# Altium schematic sheet 100_USB_HUB.SchDoc
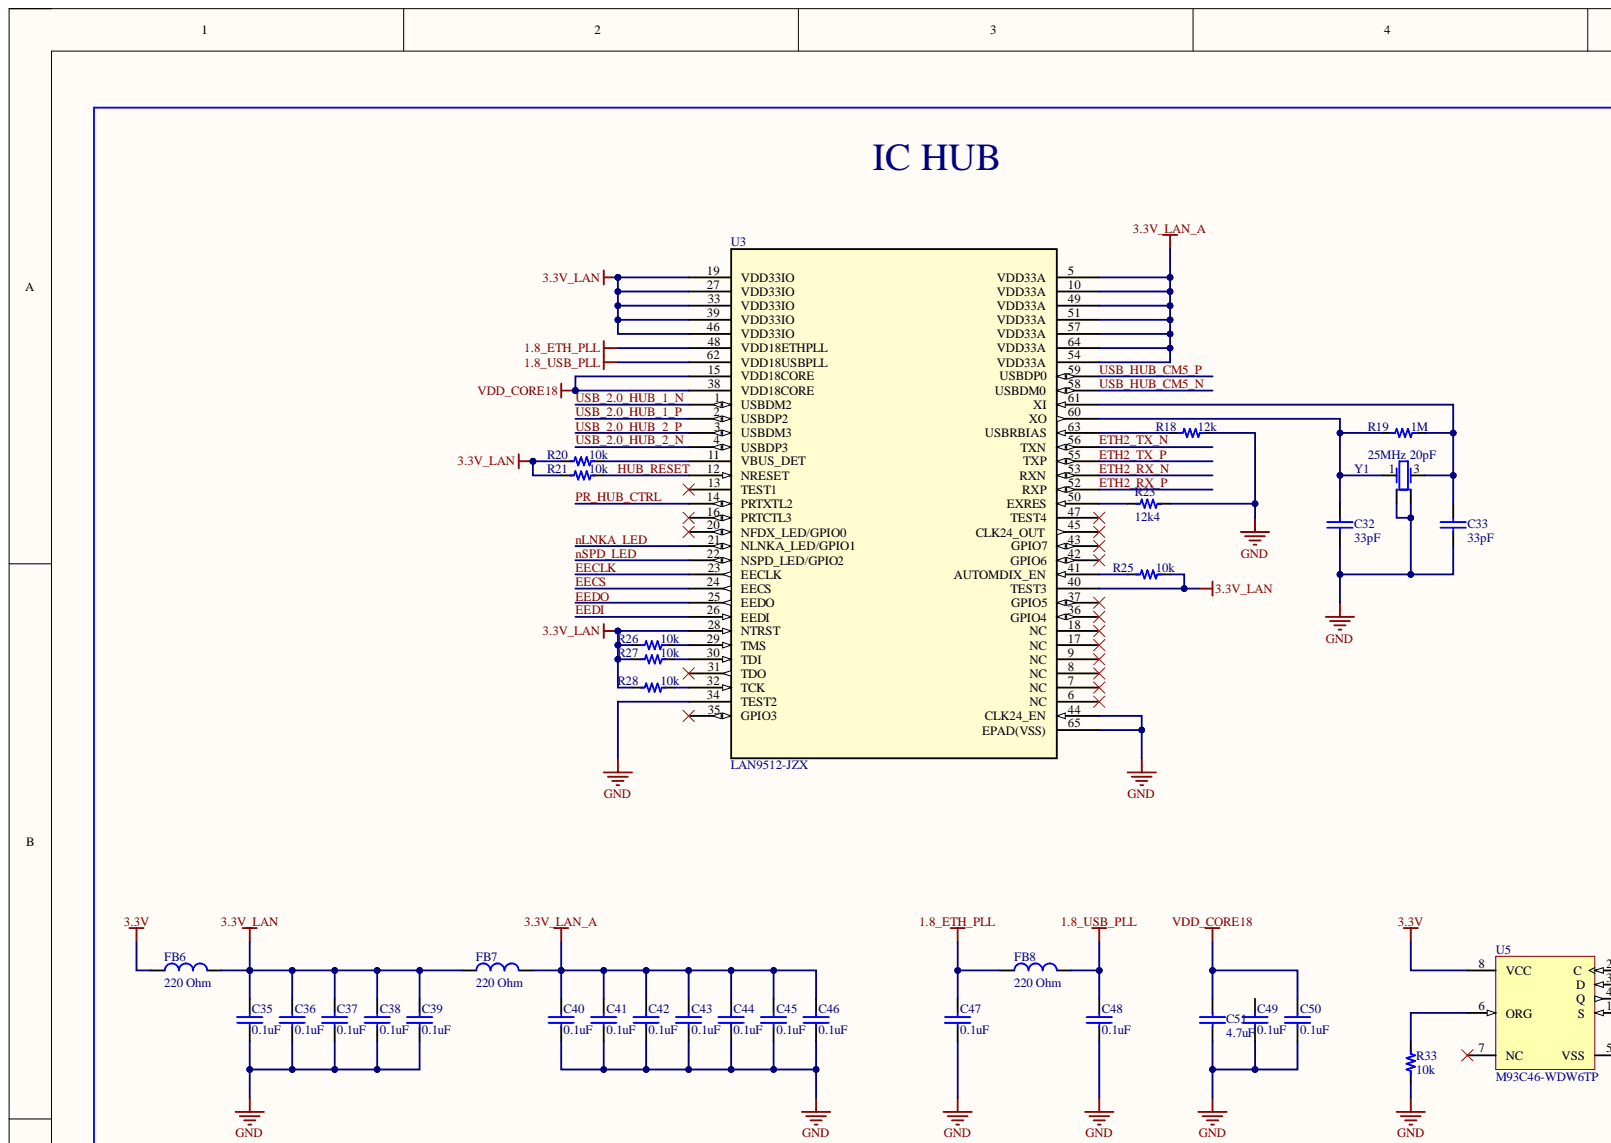
[diagram p0: 100_USB_HUB.SchDoc, top left]
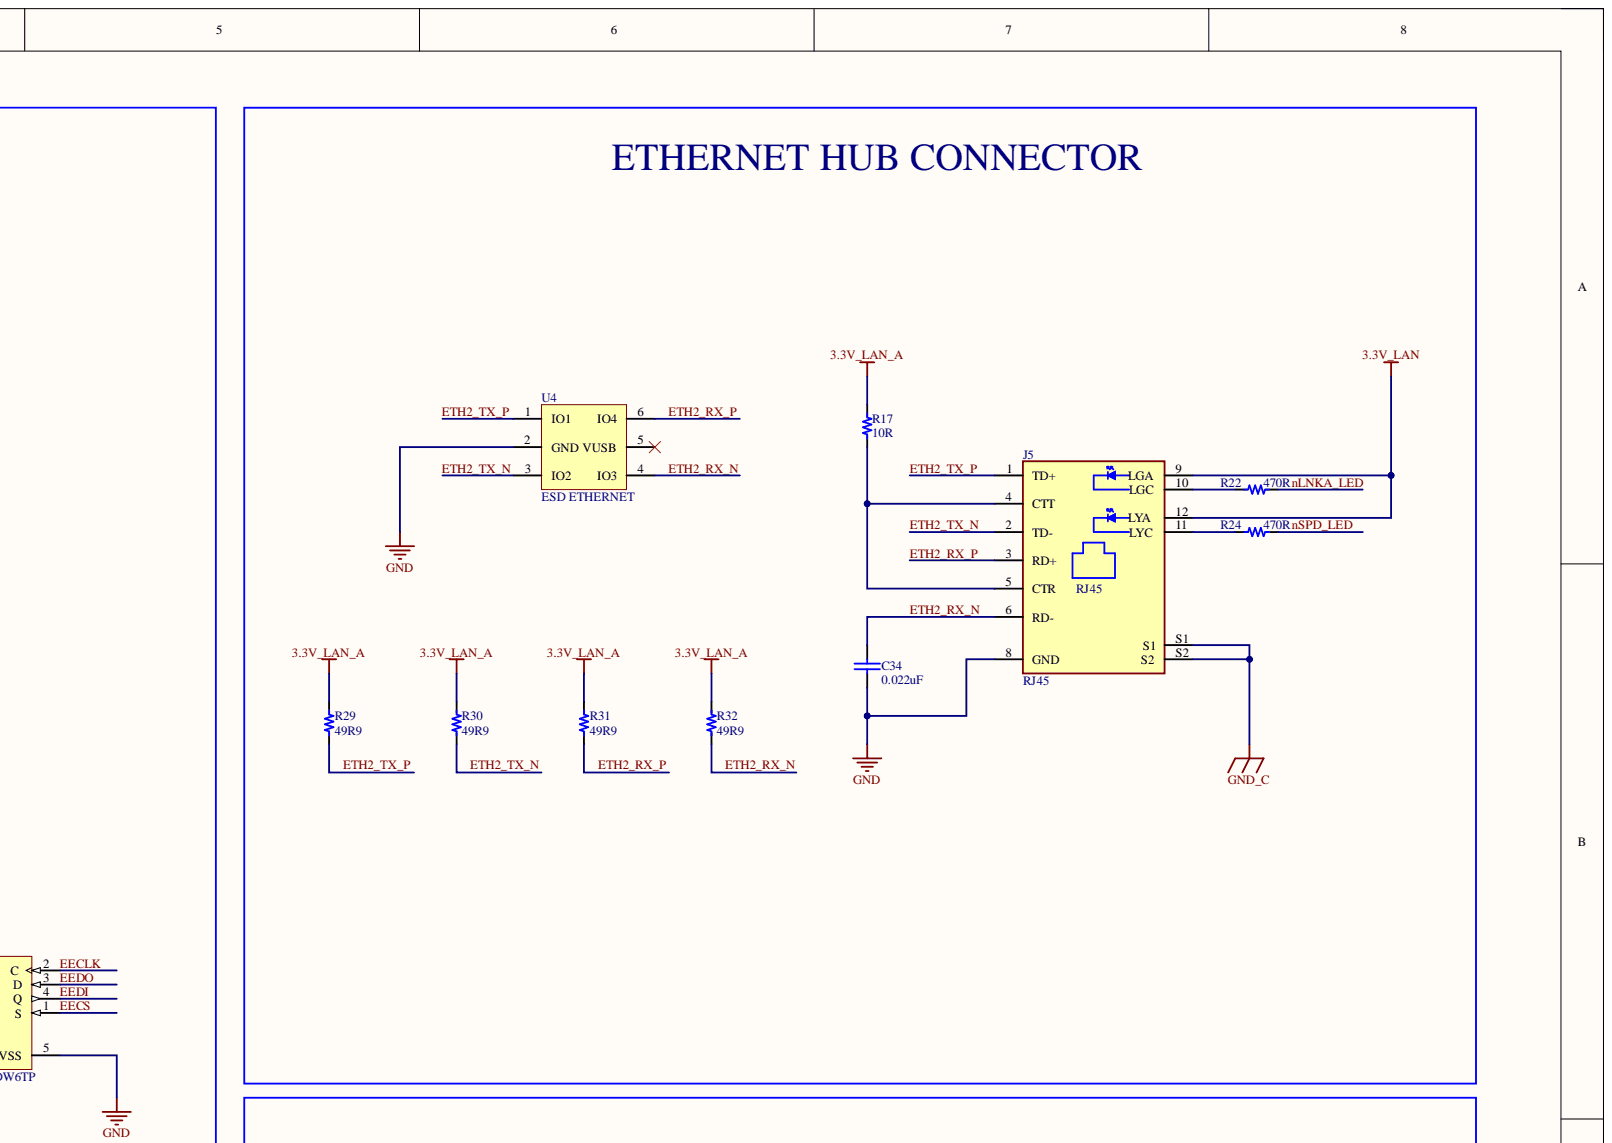
[diagram p1: 100_USB_HUB.SchDoc, top right]
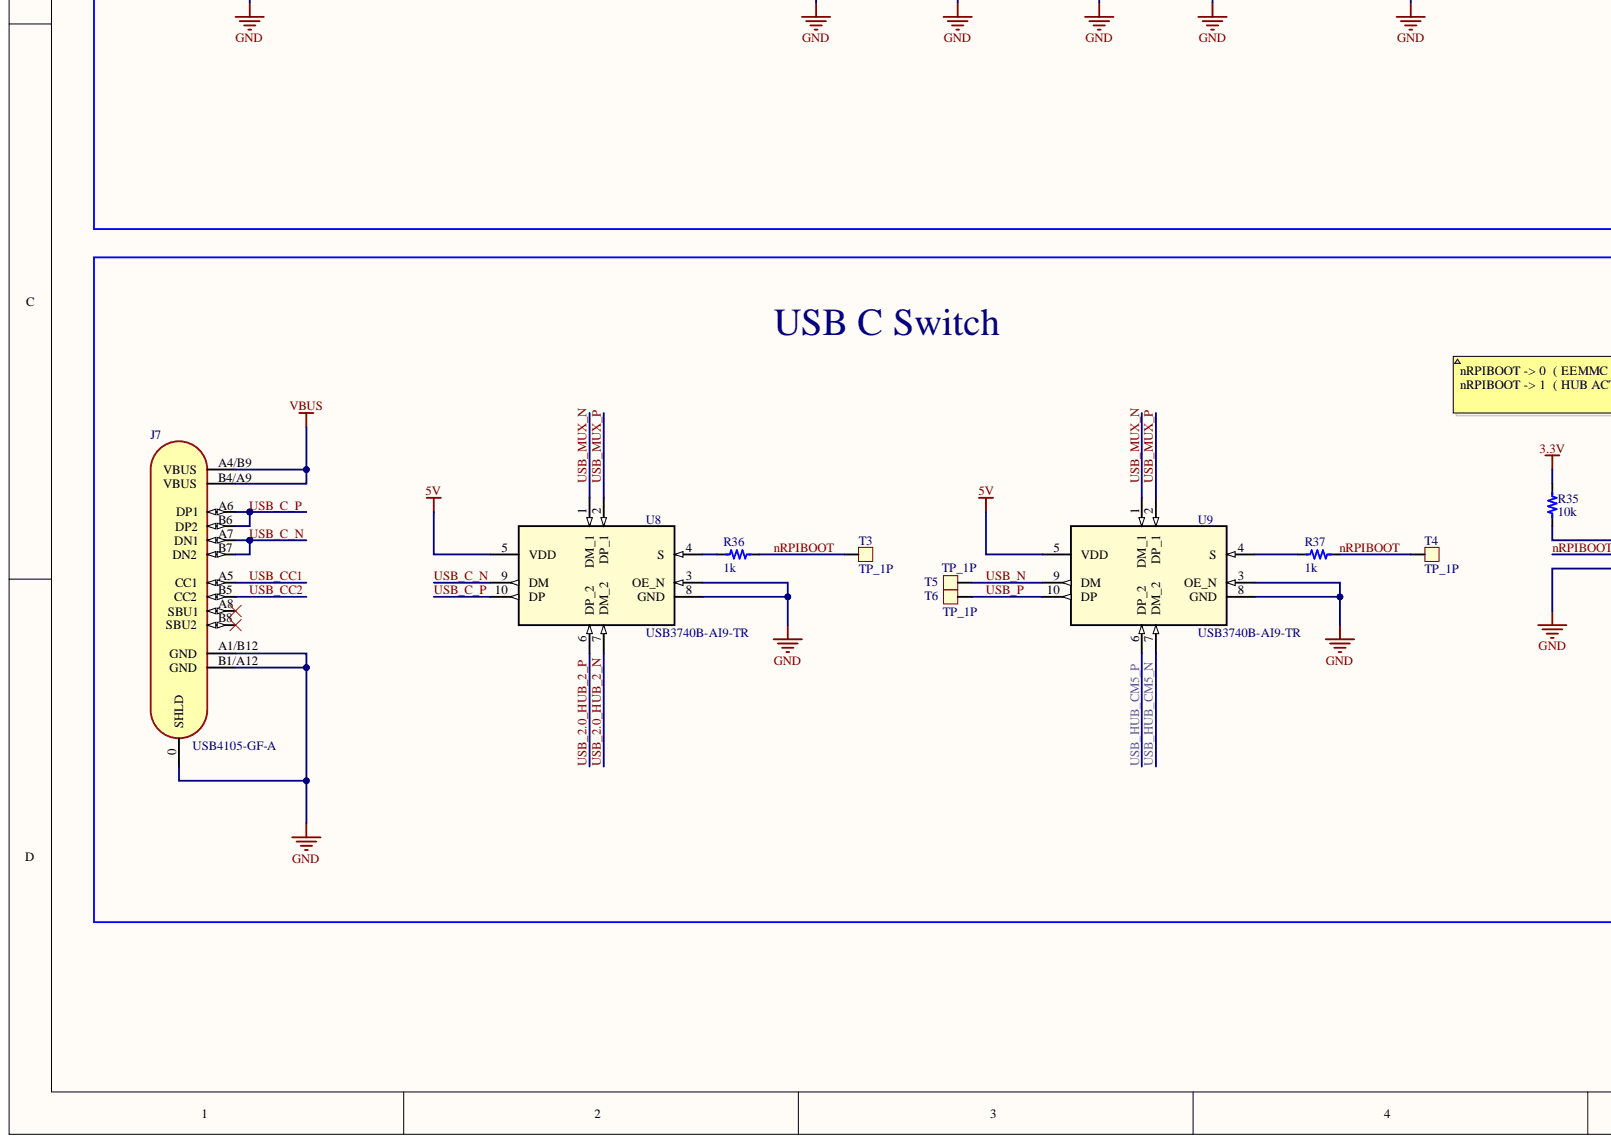
[diagram p2: 100_USB_HUB.SchDoc, bottom left]
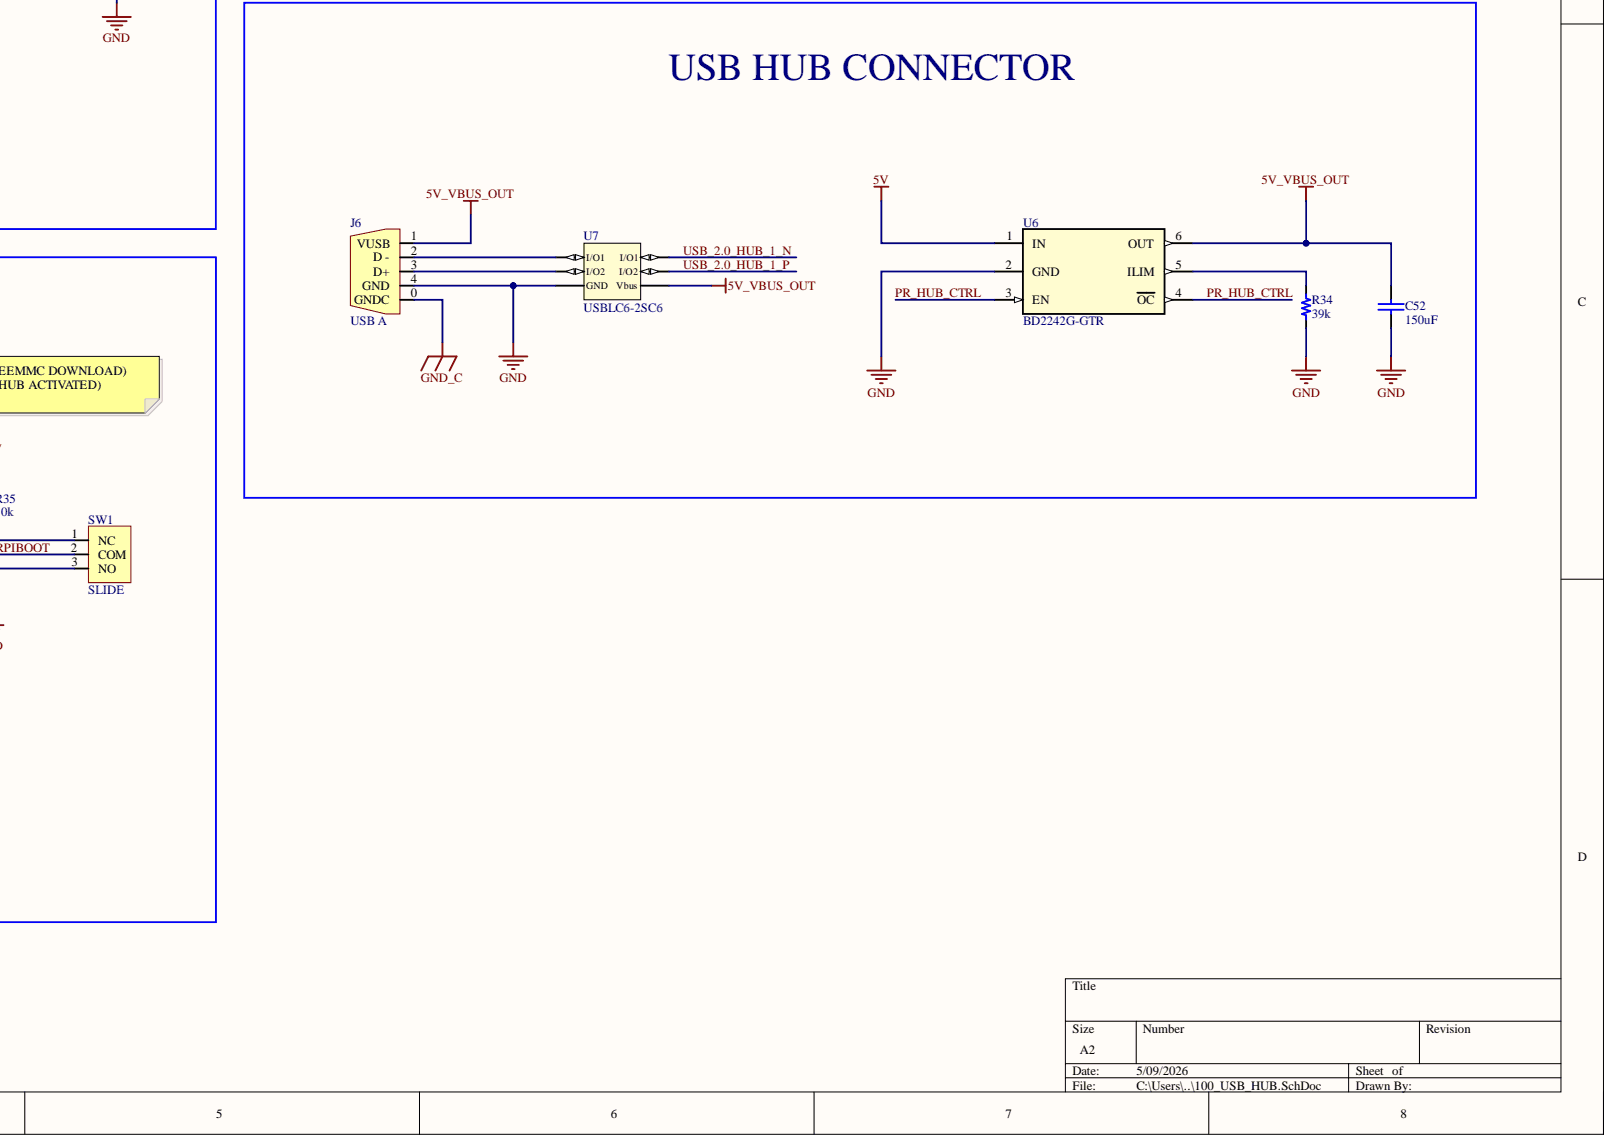
[diagram p3: 100_USB_HUB.SchDoc, bottom right]

## Parts
C32  value 33pF  [0603_1.4mm]  (hidden comment: 33pF 50V)
C33  value 33pF  [0603_1.4mm]  (hidden comment: 33pF 50V)
C34  value 0.022uF  [0402_1.0mm]  (hidden comment: 0.022uF 50V)
C35  value 0.1uF  [0603_1.4mm]  (hidden comment: 0.1uF 100V)
C36  value 0.1uF  [0603_1.4mm]  (hidden comment: 0.1uF 100V)
C37  value 0.1uF  [0603_1.4mm]  (hidden comment: 0.1uF 100V)
C38  value 0.1uF  [0603_1.4mm]  (hidden comment: 0.1uF 100V)
C39  value 0.1uF  [0603_1.4mm]  (hidden comment: 0.1uF 100V)
C40  value 0.1uF  [0603_1.4mm]  (hidden comment: 0.1uF 100V)
C41  value 0.1uF  [0603_1.4mm]  (hidden comment: 0.1uF 100V)
C42  value 0.1uF  [0603_1.4mm]  (hidden comment: 0.1uF 100V)
C43  value 0.1uF  [0603_1.4mm]  (hidden comment: 0.1uF 100V)
C44  value 0.1uF  [0603_1.4mm]  (hidden comment: 0.1uF 100V)
C45  value 0.1uF  [0603_1.4mm]  (hidden comment: 0.1uF 100V)
C46  value 0.1uF  [0603_1.4mm]  (hidden comment: 0.1uF 100V)
C47  value 0.1uF  [0603_1.4mm]  (hidden comment: 0.1uF 100V)
C48  value 0.1uF  [0603_1.4mm]  (hidden comment: 0.1uF 100V)
C49  value 0.1uF  [0603_1.4mm]  (hidden comment: 0.1uF 100V)
C50  value 0.1uF  [0603_1.4mm]  (hidden comment: 0.1uF 100V)
C51  value 4.7uF  [0603_1.4mm]  (hidden comment: 4.7uF 6.3V)
C52  value 150uF  [1210_3.2mm]  (hidden comment: 150uF 6.3V)
FB6  value 220 Ohm  [0603]  (hidden comment: 220OHM 2.2A)
FB7  value 220 Ohm  [0603]  (hidden comment: 220OHM 2.2A)
FB8  value 220 Ohm  [0603]  (hidden comment: 220OHM 2.2A)
J5  RJ45  [Con_RJ45_1Port_10/100BASE]
J6  USB A  [CON_USB_TYPE_A_FEMALE]
J7  USB4105-GF-A  [CON_USB_C_16P_RA]
R17  value 10R  [0402_1.0mm]  (hidden comment: 10R 1/16W)
R18  value 12k  [0603_1.4mm]  (hidden comment: 12k 1/10W)
R19  value 1M  [0603_1.4mm]  (hidden comment: 1M 1/10W)
R20  value 10k  [0603_1.4mm]  (hidden comment: 10k 1/10W)
R21  value 10k  [0603_1.4mm]  (hidden comment: 10k 1/10W)
R22  value 470R  [0603_1.4mm]  (hidden comment: 470R 1/10W)
R23  value 12k4  [0603_1.4mm]  (hidden comment: 12k4 1/10W)
R24  value 470R  [0603_1.4mm]  (hidden comment: 470R 1/10W)
R25  value 10k  [0603_1.4mm]  (hidden comment: 10k 1/10W)
R26  value 10k  [0603_1.4mm]  (hidden comment: 10k 1/10W)
R27  value 10k  [0603_1.4mm]  (hidden comment: 10k 1/10W)
R28  value 10k  [0603_1.4mm]  (hidden comment: 10k 1/10W)
R29  value 49R9  [0402_1.0mm]  (hidden comment: 49R9 1/16W)
R30  value 49R9  [0402_1.0mm]  (hidden comment: 49R9 1/16W)
R31  value 49R9  [0402_1.0mm]  (hidden comment: 49R9 1/16W)
R32  value 49R9  [0402_1.0mm]  (hidden comment: 49R9 1/16W)
R33  value 10k  [0603_1.4mm]  (hidden comment: 10k 1/10W)
R34  39K  [0603_1.4mm]
R35  value 10k  [0603_1.4mm]  (hidden comment: 10k 1/10W)
R36  value 1k  [0603_1.4mm]  (hidden comment: 1k 1/10W)
R37  value 1k  [0603_1.4mm]  (hidden comment: 1k 1/10W)
SW1  SLIDE  [Sw_SLIDE_SPDT_3.5x3.6x9mm]
T3  TP_1P  [TP_TH_30mil]  (no BOM)
T4  TP_1P  [TP_TH_30mil]  (no BOM)
T5  TP_1P  [TP_SMD_25mil]  (no BOM)
T6  TP_1P  [TP_SMD_25mil]  (no BOM)
U3  LAN9512-JZX  [64-VFQFN_EP]
U4  ESD ETHERNET  [SOT_23_6]
U5  M93C46-WDW6TP  [TSSOP_8_4.40MM]
U6  BD2242G-GTR  [SSOP_6_2.8X2.9MM]
U7  USBLC6-2SC6  [SOT_23_6]
U8  USB3740B-AI9-TR  [10-QFN]
U9  USB3740B-AI9-TR  [10-QFN]
Y1  25MHz 20pF  [SMD_4_3.2X2.5mm]

## Nets
1.8_ETH_PLL: C47.1 FB8.1 U3.48(VDD18ETHPLL)
1.8_USB_PLL: C48.1 FB8.2 U3.62(VDD18USBPLL)
3.3V: FB6.1 R35.1 U5.8(VCC)
3.3V_LAN: C35.1 C36.1 C37.1 C38.1 C39.1 FB6.2 FB7.1 J5.9(LGA) J5.12(LYA) R20.1 R21.1 R25.2 R26.1 R27.1 R28.1 U3.19(VDD33IO) U3.27(VDD33IO) U3.28(NTRST) U3.33(VDD33IO) U3.39(VDD33IO) U3.40(TEST3) U3.46(VDD33IO)
3.3V_LAN_A: C40.1 C41.1 C42.1 C43.1 C44.1 C45.1 C46.1 FB7.2 R17.2 R29.2 R30.2 R31.2 R32.2 U3.5(VDD33A) U3.10(VDD33A) U3.49(VDD33A) U3.51(VDD33A) U3.54(VDD33A) U3.57(VDD33A) U3.64(VDD33A)
5V: U6.1(IN) U8.5(VDD) U9.5(VDD)
5V_VBUS_OUT: C52.2 J6.1(VUSB) U6.6(OUT) U7.5(Vbus)
GND: C32.2 C33.2 C34.2 C35.2 C36.2 C37.2 C38.2 C39.2 C40.2 C41.2 C42.2 C43.2 C44.2 C45.2 C46.2 C47.2 C48.2 C49.2 C50.2 C51.2 C52.1 J5.8(GND) J6.4(GND) J7.0(SHLD) J7.A1/B12(GND) J7.B1/A12(GND) R18.2 R23.2 R33.1 R34.1 SW1.3 U3.34(TEST2) U3.44(CLK24_EN) U3.65(EPAD(VSS)) U4.2(GND) U5.5(VSS) U6.2(GND) U7.2(GND) U8.3(OE_N) U8.8(GND) U9.3(OE_N) U9.8(GND) Y1.2 Y1.4
GND_C: J5.S1 J5.S2 J6.0(GNDC)
VBUS: J7.A4/B9(VBUS) J7.B4/A9(VBUS)
VDD_CORE18: C50.1 C51.1 U3.15(VDD18CORE) U3.38(VDD18CORE)
EECLK: U3.23(EECLK) U5.2(C)
EECS: U3.24(EECS) U5.1(S)
EEDI: U3.26(EEDI) U5.4(Q)
EEDO: U3.25(EEDO) U5.3(D)
ETH2_RX_N: C34.1 J5.6(RD-) R32.1 U3.53(RXN) U4.4(IO3)
ETH2_RX_P: J5.3(RD+) R31.1 U3.52(RXP) U4.6(IO4)
ETH2_TX_N: J5.2(TD-) R30.1 U3.56(TXN) U4.3(IO2)
ETH2_TX_P: J5.1(TD+) R29.1 U3.55(TXP) U4.1(IO1)
HUB_RESET: R21.2 U3.12(NRESET)
nLNKA_LED: R22.2 U3.21(NLNKA_LED/GPIO1)
nRPIBOOT: R36.2 R37.2 SW1.2 T3.1 T4.1
nSPD_LED: R24.2 U3.22(NSPD_LED/GPIO2)
PR_HUB_CTRL: U3.14(PRTXTL2) U6.3(EN) U6.4(OC)
USB_2.0_HUB_1_N: U3.1(USBDM2) U7.6(I/O1)
USB_2.0_HUB_1_P: U3.2(USBDP2) U7.4(I/O2)
USB_2.0_HUB_2_N: U3.4(USBDP3) U8.7(DM_2)
USB_2.0_HUB_2_P: U3.3(USBDM3) U8.6(DP_2)
USB_C_N: J7.A7(DN1) J7.B7(DN2) U8.9(DM)
USB_C_P: J7.A6(DP1) J7.B6(DP2) U8.10(DP)
USB_CC1: J7.A5(CC1)
USB_CC2: J7.B5(CC2)
USB_HUB_CM5_N: U3.58(USBDM0) U9.7(DM_2)
USB_HUB_CM5_P: U3.59(USBDP0) U9.6(DP_2)
USB_MUX_N: U8.1(DM_1) U9.1(DM_1)
USB_MUX_P: U8.2(DP_1) U9.2(DP_1)
USB_N: T5.1 U9.9(DM)
USB_P: T6.1 U9.10(DP)
NetC32_1: C32.1 R19.1 U3.60(XO) Y1.1
NetC33_1: C33.1 R19.2 U3.61(XI) Y1.3
NetJ5_4: J5.4(CTT) J5.5(CTR) R17.1
NetJ5_10: J5.10(LGC) R22.1
NetJ5_11: J5.11(LYC) R24.1
NetJ6_2: J6.2(D_-) U7.1(I/O1)
NetJ6_3: J6.3(D+) U7.3(I/O2)
NetR18_1: R18.1 U3.63(USBRBIAS)
NetR20_2: R20.2 U3.11(VBUS_DET)
NetR23_1: R23.1 U3.50(EXRES)
NetR25_1: R25.1 U3.41(AUTOMDIX_EN)
NetR26_2: R26.2 U3.29(TMS)
NetR27_2: R27.2 U3.30(TDI)
NetR28_2: R28.2 U3.32(TCK)
NetR33_2: R33.2 U5.6(ORG)
NetR34_2: R34.2 U6.5(ILIM)
NetR35_2: R35.2 SW1.1
NetR36_1: R36.1 U8.4(S)
NetR37_1: R37.1 U9.4(S)

Not connected: C49 1; J7 A8(SBU1) B8(SBU2); U3 6(NC) 7(NC) 8(NC) 9(NC) 13(TEST1) 16(PRTCTL3) 17(NC) 18(NC) 20(NFDX_LED/GPIO0) 31(TDO) 35(GPIO3) 36(GPIO4) 37(GPIO5) 42(GPIO6) 43(GPIO7) 45(CLK24_OUT) 47(TEST4); U4 5(VUSB); U5 7(NC)

## Library data of the parts
C32 C33 = Cap_33pF_0603_50V: CAP CER 33PF 50V C0G/NP0 0603; Manufacturer: Walsin Technologies; Manufacturer Part Number: 0603N330J500CT; Component Kind: Standard; ComponentLink1Description: Digi-Key; ComponentLink1URL: https://www.digikey.com/en/products/detail/walsin-technology-corporation/0603N330J500CT/6707550?s=N4IgTCBcDaIIxgJxgLRwGwBYCsaUDkAREAXQF8g; Last modified date: 20250321; Library Name: Capacitors.IntLib; Supplier 1: Digi-Key; Supplier Part Number 1: 1292-1645-1-ND
C34 = Cap_0.022uF_0402_50V: CAP CER 0.022UF 50V X7R 0402; Manufacturer: YAGEO; Manufacturer Part Number: CC0402KRX7R9BB223; Component Kind: Standard; ComponentLink1Description: Digi-Key; ComponentLink1URL: https://www.digikey.com/en/products/detail/yageo/CC0402KRX7R9BB223/5195131?s=N4IgTCBcDaIMJwAwBZFgNICUAaB2TAnAEJFhgDMIAugL5A; Last modified date: 20250321; Library Name: Capacitors.IntLib; Supplier 1: Digi-Key; Supplier Part Number 1: 311-1722-1-ND
C35-C50 = Cap_0.1uF_0603_100V: CAP CER 0.1UF 100V X7R 0603; Manufacturer: Samsung Electro-Mechanics; Manufacturer Part Number: CL10B104KC8NNNC; Component Kind: Standard; ComponentLink1Description: Digi-Key; ComponentLink1URL: https://www.digikey.com/en/products/detail/samsung-electro-mechanics/CL10B104KC8NNNC/5961291; Last modified date: 20250321; Library Name: Capacitors.IntLib; Supplier 1: Digi-Key; Supplier Part Number 1: 1276-6807-1-ND
C51 = Cap_4.7uF_0603_6.3V_X7R: CAP CER 4.7UF 6.3V X7R 0603; Manufacturer: Samsung Electro-Mechanics; Manufacturer Part Number: CL10B475KQ8NQNC; Component Kind: Standard; ComponentLink1Description: Digi-Key; ComponentLink1URL: https://www.digikey.com/en/products/detail/samsung-electro-mechanics/CL10B475KQ8NQNC/3887745?s=N4IgTCBcDaIMIBkCMAGAQgFgOwFYDSAigBwByBJcIAugL5A; Last modified date: 20250704; Library Name: Capacitors.IntLib; Supplier 1: Digi-Key; Supplier Part Number 1: 1276-2087-1-ND
C52 = Cap_150uF_1210_6.3V: CAP CER 150UF 6.3V X5R 1210; Manufacturer: Samsung Electro-Mechanics; Manufacturer Part Number: CL32A157MQVNNNE; Component Kind: Standard; ComponentLink1Description: Digi-Key; ComponentLink1URL: https://www.digikey.com/en/products/detail/samsung-electro-mechanics/CL32A157MQVNNNE/3889025?s=N4IgTCBcDaIMIBkDMYCCBGArAdgLIEUA1AOVIFEQBdAXyA; Last modified date: 20240305; Library Name: Capacitors.IntLib; Supplier 1: Digi-Key; Supplier Part Number 1: 1276-3367-1-ND; Last modified by: J-E.Pachon
FB6-FB8 = FB_220_0603_2.2A: FERRITE BEAD 220 OHM 0603 1LN; Manufacturer: TDK Corporation; Manufacturer Part Number: MPZ1608S221ATA00; Component Kind: Standard; ComponentLink1Description: Digi-Key; ComponentLink1URL: https://www.digikey.com/en/products/detail/tdk-corporation/MPZ1608S221ATA00/571872; Last modified date: 20250321; Library Name: Filters.IntLib; Supplier 1: Digi-Key; Supplier Part Number 1: 445-1565-1-ND
J5 = Con_RJ45_1Port_100base_Magnetics: RJ45Receptacle 1 WithLED Plugin Ethernet Connectors / Modular Connectors (RJ45 RJ11) ROHS; Manufacturer: HANRUN(Zhongshan HanRun Elec); Manufacturer Part Number: HR913550A; Component Kind: Standard; ComponentLink1Description: LCSC; ComponentLink1URL: https://www.lcsc.com/product-detail/Ethernet-Connectors-Modular-Connectors-RJ45-RJ11_HANRUN-Zhongshan-HanRun-Elec-HR913550A_C163507.html; Last modified date: 20250321; Library Name: Connectors.IntLib; Supplier 1: LCSC; Supplier Part Number 1: C163507
J6 = Con_USB_A_Female: USB - Type A Female USB 2.0 4 Through Hole USB Connectors RoHS; Manufacturer: Jing Extension of the Electronic Co.; Manufacturer Part Number: 903-131A1011D10100; Component Kind: Standard; ComponentLink1Description: LCSC; ComponentLink1URL: https://lcsc.com/product-detail/USB-Connectors_Jing-Extension-of-the-Electronic-Co-A-F90-White-plastic-Not-high-temperature_C46407.html; Last modified date: 20250321; Library Name: Connectors.IntLib; Supplier 1: LCSC; Supplier Part Number 1: C46407
J7 = Con_USB_C_16P_RA: CONN RCP USB2.0 TYP C 24P SMD RA; Manufacturer: GCT; Component Kind: Standard; ComponentLink1Description: DigiKey; ComponentLink1URL: https://www.digikey.com/en/products/detail/gct/USB4105-GF-A/11198441; Last modified date: 20251003; Library Name: Connectors.IntLib; Manufacturer Part Number: USB4105-GF-A; Supplier 1: DigiKey; Supplier Part Number 1: 2073-USB4105-GF-ACT-ND
R17 = Res_10R_0402_1/16W: RES 10 OHM 1% 1/16W 0402; Manufacturer: YAGEO; Manufacturer Part Number: RC0402FR-0710RL; Component Kind: Standard; ComponentLink1Description: Digi-Key; ComponentLink1URL: https://www.digikey.com/en/products/detail/yageo/RC0402FR-0710RL/726524; Last modified date: 20250321; Supplier 1: Digi-Key; Supplier Part Number 1: 311-10.0LRCT-ND; Library Name: Resistors-potenciometers.IntLib
R18 = Res_12k_0603_1/10W: RES SMD 12K OHM 1% 1/10W 0603; Manufacturer: Vishay Dale; Manufacturer Part Number: CRCW060312K0FKEAC; Component Kind: Standard; ComponentLink1Description: Digi-Key; ComponentLink1URL: https://www.digikey.com/product-detail/en/vishay-dale/CRCW060312K0FKEAC/541-4046-1-ND/7928729; Last modified date: 20250321; Supplier 1: Digi-Key; Supplier Part Number 1: 541-4046-1-ND; Library Name: Resistors-potenciometers.IntLib
R19 = Res_1M_0603_1/10W: RES SMD 1M OHM 1% 1/10W 0603; Manufacturer: TE Connectivity Passive Product; Manufacturer Part Number: CRG0603F1M0; Component Kind: Standard; ComponentLink1Description: Digi-Key; ComponentLink1URL: https://www.digikey.com/product-detail/en/te-connectivity-passive-product/CRG0603F1M0/A121559CT-ND/5246506; Last modified date: 20250321; Supplier 1: Digi-Key; Supplier Part Number 1: A121559CT-ND; Library Name: Resistors-potenciometers.IntLib
R20 R21 R25-R28 R33 R35 = Res_10k_0603_1/10W: RES SMD 10K OHM 1% 1/10W 0603; Manufacturer: Samsung Electro-Mechanics; Manufacturer Part Number: RC1608F103CS; Component Kind: Standard; ComponentLink1Description: Digi-key; ComponentLink1URL: https://www.digikey.com/products/en?keywords=1276-3485-1-ND; Last modified date: 20250321; Supplier 1: Digi-Key; Supplier Part Number 1: 1276-3485-1-ND; Library Name: Resistors-potenciometers.IntLib
R22 R24 = Res_470R_0603_1/10W: RES 470 OHM 1% 1/10W 0603; Manufacturer: Yageo; Manufacturer Part Number: RC0603FR-07470RL; Component Kind: Standard; ComponentLink1Description: Digi-Key; ComponentLink1URL: https://www.digikey.com/product-detail/en/yageo/RC0603FR-07470RL/311-470HRCT-ND/730203; Last modified date: 20250321; Supplier 1: Digi-Key; Supplier Part Number 1: 311-470HRCT-ND; Library Name: Resistors-potenciometers.IntLib
R23 = Res_12k4_0603_1/10W: RES 12.4K OHM 1% 1/10W 0603; Manufacturer: YAGEO; Manufacturer Part Number: RC0603FR-0712K4L; Component Kind: Standard; ComponentLink1Description: Digi-Key; ComponentLink1URL: https://www.digikey.com/en/products/detail/yageo/RC0603FR-0712K4L/726917; Last modified date: 20250321; Supplier 1: Digi-Key; Supplier Part Number 1: 311-12.4KHRCT-ND; Library Name: Resistors-potenciometers.IntLib
R29-R32 = Res_49R9_0402_1/16W: RES SMD 49.9 OHM 1% 1/16W 0402; Manufacturer: Yageo; Manufacturer Part Number: RC0402FR-0749R9L; Component Kind: Standard; ComponentLink1Description: Digi-key; ComponentLink1URL: https://www.digikey.com/product-detail/en/yageo/RC0402FR-0749R9L/311-49.9LRCT-ND/729568; Last modified date: 20250321; Supplier 1: Digi-key; Supplier Part Number 1: 311-49.9LRCT-ND; Library Name: Resistors-potenciometers.IntLib
R34 = Res_39K_0603_1/10W: RES SMD 39k OHM 1% 1/10W 0603; Manufacturer: Yageo; Manufacturer Part Number: AC0603FR-0739KL; Component Kind: Standard; ComponentLink1Description: Digi-Key; ComponentLink1URL: https://www.digikey.com/product-detail/en/yageo/AC0603FR-0739KL/YAG3603TR-ND/5896077; Last modified date: 20250321; Supplier 1: Digi-Key; Supplier Part Number 1: YAG3603CT-ND; Value: 39k; Library Name: Resistors-potenciometers.IntLib
R36 R37 = Res_1k_0603_1/10W: RES SMD 1K OHM 1% 1/10W 0603; Manufacturer: Yageo; Manufacturer Part Number: RC0603FR-071KL; Component Kind: Standard; ComponentLink1Description: Digi-Key; ComponentLink1URL: https://www.digikey.com/product-detail/en/yageo/RC0603FR-071KL/311-1-00KHRCT-ND/729790; Last modified date: 20250321; Supplier 1: Digi-Key; Supplier Part Number 1: 311-1.00KHRCT-ND; Library Name: Resistors-potenciometers.IntLib
SW1 = Sw_SLIDE_SPDT_3.5x3.6x9mm_SMD_RA: SWITCH SLIDE SPDT 300MA 6V; Manufacturer: C&K; Manufacturer Part Number: JS102011SAQN; Component Kind: Standard; ComponentLink1Description: Digi-Key; ComponentLink1URL: https://www.digikey.com/product-detail/en/c-k/JS102011SAQN/401-1999-1-ND/1640114; Last modified date: 20250321; Library Name: Switches-relays.IntLib; Supplier 1: Digi-Key; Supplier Part Number 1: 401-1999-1-ND
T3 T4 = TP_TH_30mil: Test point 30mil hole size; Last modified date: 20250321; Library Name: Connectors.IntLib
T5 T6 = TP_SMD_25mil: Test point 25mil pad size; Last modified date: 20250321; Library Name: Connectors.IntLib
U3 = IC_HUB_ETH_USB2.0_LAN9512-JZX: IC USB 2.0 ETHER CTRLR 64QFN; Manufacturer: Microchip Technology; Component Kind: Standard; ComponentLink1Description: Digi-Key; ComponentLink1URL: https://www.digikey.com/en/products/detail/microchip-technology/LAN9512-JZX/2166038; Last modified date: 20260406; Library Name: ICs.IntLib; Manufacturer Part Number: LAN9512-JZX; Supplier 1: Digi-Key; Supplier Part Number 1: 638-1086-ND
U4 = TVS_5.25V_4CH_SOT_23_6: TVS DIODE 5.25V 17V SOT23-6; Manufacturer: STMicroelectronics; Manufacturer Part Number: USBLC6-4SC6; Component Kind: Standard; ComponentLink1Description: Digi-Key; ComponentLink1URL: https://www.digikey.com/product-detail/en/stmicroelectronics/USBLC6-4SC6/497-4492-1-ND/725667; Last modified date: 20250321; Library Name: Circuit_protection.IntLib; Supplier 1: Digi-Key; Supplier Part Number 1: 497-4492-1-ND
U5 = IC_EEPROM_1Kb_2MHz_M93C46: IC EEPROM 1KBIT SPI 2MHZ 8TSSOP; Manufacturer: STMicroelectronics; Component Kind: Standard; ComponentLink1Description: Digi-Key; ComponentLink1URL: https://www.digikey.com/en/products/detail/stmicroelectronics/M93C46-WDW6TP/1664121; Last modified date: 20250321; Library Name: ICs.IntLib; Manufacturer Part Number: M93C46-WDW6TP; Supplier 1: Digi-Key; Supplier Part Number 1: 497-8655-1-ND
U6 = IC_POWER_SWITCH_1-CH_ADJ_EN_H: 1 Active High 1.5A High Side Switch SSOP-6-1.6mm Power Distribution Switches, Load Drivers RoHS; Manufacturer: ROHM Semicon; Component Kind: Standard; ComponentLink1Description: LCSC; ComponentLink1URL: https://www.lcsc.com/product-detail/C559606.html?s_z=n_q_BD2242G&spm=wm.ssy.bg.0.xh&lcsc_vid=FlBYVlZSElEMVFEDRABeUQIFEVhYBAACFgUPBAJRRlIxVlNRQFVWX1JQT1NWUDsOAxUeFF5JWBYZEEoKFBINSQcJGk4eFQsCAgIaSgADAwAHC0slT1VXX1xIHxUDCw%3D%3D; Last modified date: 20260429; Library Name: ICs.IntLib; Manufacturer Part Number: BD2242G-GTR; Supplier 1: LCSC; Supplier Part Number 1: C559606
U7 = TVS_5.25V_2CH_SOT_23_6: TVS DIODE 5.25VWM 17VC SOT23-6; Manufacturer: STMicroelectronics; Component Kind: Standard; ComponentLink1Description: Digi-Key; ComponentLink1URL: https://www.digikey.com/products/en?keywords=497-5235-1-ND; Last modified date: 20250321; Library Name: Circuit_protection.IntLib; Manufacturer Part Number: USBLC6-2SC6; Supplier 1: Digi-Key; Supplier Part Number 1: 497-5235-1-ND
U8 U9 = IC_USB_MUX_2CH_10-QFN: IC CONTROLLER USB 10QFN; Manufacturer: Microchip Technology; Component Kind: Standard; ComponentLink1Description: Digi-Key; ComponentLink1URL: https://www.digikey.com/en/products/detail/microchip-technology/USB3740B-AI9-TR/3873206?s=N4IgTCBcDaIKoGUBCBmA7AFgAxILQEEBJATlwBUAlEAXQF8g; Last modified date: 20250321; Library Name: ICs.IntLib; Manufacturer Part Number: USB3740B-AI9-TR; Supplier 1: Digi-Key; Supplier Part Number 1: USB3740B-AI9-TRCT-ND
Y1 = Xtal_25MHz_20pF_3.2x2.5mm: CRYSTAL 25.0000MHZ 20PF SMD; Manufacturer: ECS Inc.; Manufacturer Part Number: ECS-250-20-33-TR3; Component Kind: Standard; ComponentLink1Description: Digi-Key; ComponentLink1URL: https://www.digikey.tw/en/products/detail/ecs-inc/ECS-250-20-33-TR3/14825143; Last modified date: 20250321; Library Name: Crystals-oscillators.IntLib; Supplier 1: Digi-Key; Supplier Part Number 1: 50-ECS-250-20-33-TR3CT-ND
